# Altium schematic sheet OUT_B5.SchDoc
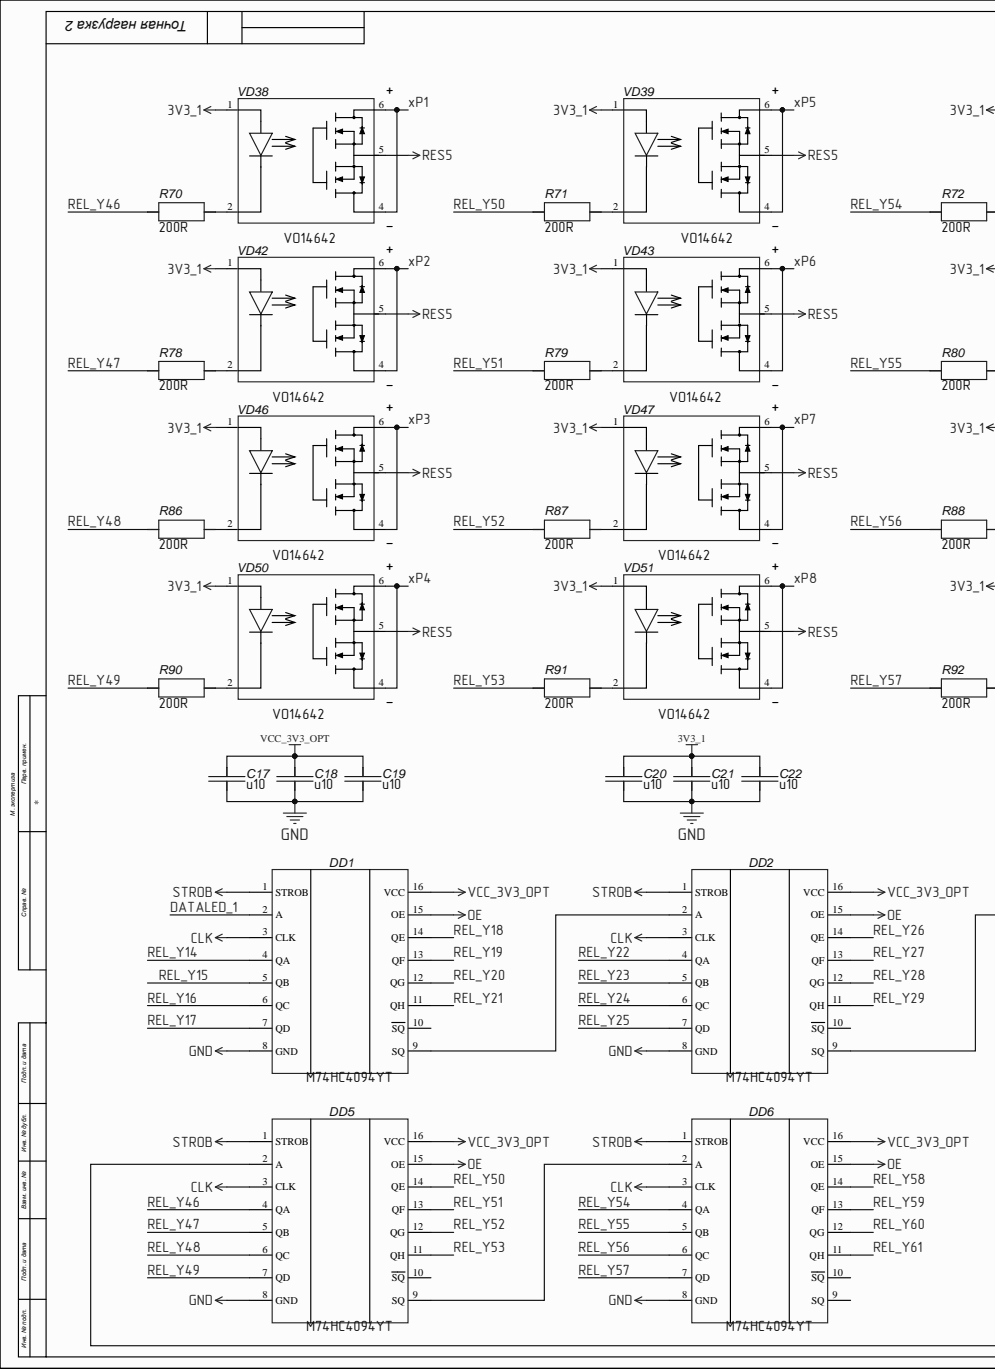
[diagram p0: OUT_B5.SchDoc, left]
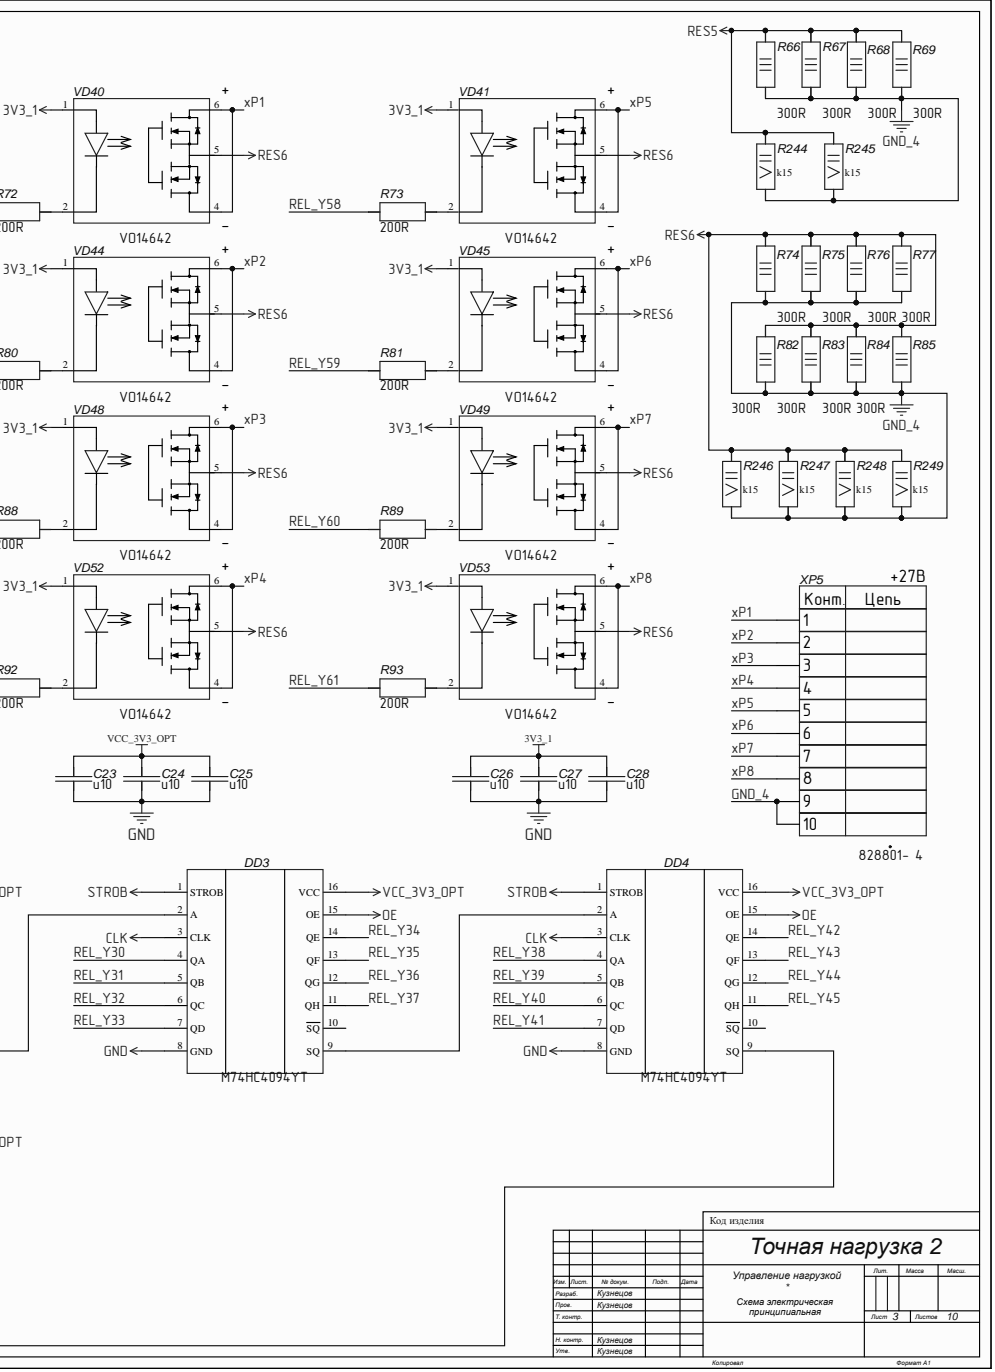
[diagram p1: OUT_B5.SchDoc, right]

## Parts
C17  u10
C18  u10
C19  u10
C20  u10
C21  u10
C22  u10
C23  u10
C24  u10
C25  u10
C26  u10
C27  u10
C28  u10
DD1  M74HC4094YT  [TSOOP-16]
DD2  M74HC4094YT  [TSOOP-16]
DD3  M74HC4094YT  [TSOOP-16]
DD4  M74HC4094YT  [TSOOP-16]
DD5  M74HC4094YT  [TSOOP-16]
DD6  M74HC4094YT  [TSOOP-16]
R66  value 300R  [R3_0]  (hidden comment: PAC03)
R67  value 300R  [R3_0]  (hidden comment: PAC03)
R68  value 300R  [R3_0]  (hidden comment: PAC03)
R69  value 300R  [R3_0]  (hidden comment: PAC03)
R70  200R  [0805]
R71  200R  [0805]
R72  200R  [0805]
R73  200R  [0805]
R74  300R  [R3_0]
R75  value 300R  [R3_0]  (hidden comment: PAC03)
R76  value 300R  [R3_0]  (hidden comment: PAC03)
R77  value 300R  [R3_0]  (hidden comment: PAC03)
R78  200R  [0805]
R79  200R  [0805]
R80  200R  [0805]
R81  200R  [0805]
R82  value 300R  [R3_0]  (hidden comment: PAC03)
R83  value 300R  [R3_0]  (hidden comment: PAC03)
R84  value 300R  [R3_0]  (hidden comment: PAC03)
R85  value 300R  [R3_0]  (hidden comment: PAC03)
R86  200R  [0805]
R87  200R  [0805]
R88  200R  [0805]
R89  200R  [0805]
R90  200R  [0805]
R91  200R  [0805]
R92  200R  [0805]
R93  200R  [0805]
R244  value k15  [RH005  7,5W]  (hidden comment: RH005)
R245  value k15  [RH005  7,5W]  (hidden comment: RH005)
R246  value k15  [RH005  7,5W]  (hidden comment: RH005)
R247  value k15  [RH005  7,5W]  (hidden comment: RH005)
R248  value k15  [RH005  7,5W]  (hidden comment: RH005)
R249  value k15  [RH005  7,5W]  (hidden comment: RH005)
VD38  VO14642  [SMD-6]
VD39  VO14642  [SMD-6]
VD40  VO14642  [SMD-6]
VD41  VO14642  [SMD-6]
VD42  VO14642  [SMD-6]
VD43  VO14642  [SMD-6]
VD44  VO14642  [SMD-6]
VD45  VO14642  [SMD-6]
VD46  VO14642  [SMD-6]
VD47  VO14642  [SMD-6]
VD48  VO14642  [SMD-6]
VD49  VO14642  [SMD-6]
VD50  VO14642  [SMD-6]
VD51  VO14642  [SMD-6]
VD52  VO14642  [SMD-6]
VD53  VO14642  [SMD-6]
XP5  828801- 4  [828801-4]

## Nets
3V3_1: C20.2 C21.2 C22.2 C26.2 C27.2 C28.2 VD38.1 VD39.1 VD40.1 VD41.1 VD42.1 VD43.1 VD44.1 VD45.1 VD46.1 VD47.1 VD48.1 VD49.1 VD50.1 VD51.1 VD52.1 VD53.1
CLK: DD1.3(CLK) DD2.3(CLK) DD3.3(CLK) DD4.3(CLK) DD5.3(CLK) DD6.3(CLK)
GND: C17.1 C18.1 C19.1 C20.1 C21.1 C22.1 C23.1 C24.1 C25.1 C26.1 C27.1 C28.1 DD1.8(GND) DD2.8(GND) DD3.8(GND) DD4.8(GND) DD5.8(GND) DD6.8(GND)
OE: DD1.15(OE) DD2.15(OE) DD3.15(OE) DD4.15(OE) DD5.15(OE) DD6.15(OE)
RES5: R66.2 R67.2 R68.2 R69.2 R244.2 R245.2 VD38.5 VD39.5 VD42.5 VD43.5 VD46.5 VD47.5 VD50.5 VD51.5
RES6: R74.2 R75.2 R76.2 R77.2 R82.2 R83.2 R84.2 R85.2 R246.2 R247.2 R248.2 R249.2 VD40.5 VD41.5 VD44.5 VD45.5 VD48.5 VD49.5 VD52.5 VD53.5
STROB: DD1.1(STROB) DD2.1(STROB) DD3.1(STROB) DD4.1(STROB) DD5.1(STROB) DD6.1(STROB)
VCC_3V3_OPT: C17.2 C18.2 C19.2 C23.2 C24.2 C25.2 DD1.16(VCC) DD2.16(VCC) DD3.16(VCC) DD4.16(VCC) DD5.16(VCC) DD6.16(VCC)
DATALED_1: DD1.2(A)
GND_4: R66.1 R67.1 R68.1 R69.1 R74.1 R75.1 R76.1 R77.1 R82.1 R83.1 R84.1 R85.1 R244.1 R245.1 R246.1 R247.1 R248.1 R249.1 XP5.9 XP5.10
REL_Y14: DD1.4(QA)
REL_Y15: DD1.5(QB)
REL_Y16: DD1.6(QC)
REL_Y17: DD1.7(QD)
REL_Y18: DD1.14(QE)
REL_Y19: DD1.13(QF)
REL_Y20: DD1.12(QG)
REL_Y21: DD1.11(QH)
REL_Y22: DD2.4(QA)
REL_Y23: DD2.5(QB)
REL_Y24: DD2.6(QC)
REL_Y25: DD2.7(QD)
REL_Y26: DD2.14(QE)
REL_Y27: DD2.13(QF)
REL_Y28: DD2.12(QG)
REL_Y29: DD2.11(QH)
REL_Y30: DD3.4(QA)
REL_Y31: DD3.5(QB)
REL_Y32: DD3.6(QC)
REL_Y33: DD3.7(QD)
REL_Y34: DD3.14(QE)
REL_Y35: DD3.13(QF)
REL_Y36: DD3.12(QG)
REL_Y37: DD3.11(QH)
REL_Y38: DD4.4(QA)
REL_Y39: DD4.5(QB)
REL_Y40: DD4.6(QC)
REL_Y41: DD4.7(QD)
REL_Y42: DD4.14(QE)
REL_Y43: DD4.13(QF)
REL_Y44: DD4.12(QG)
REL_Y45: DD4.11(QH)
REL_Y46: DD5.4(QA) R70.2
REL_Y47: DD5.5(QB) R78.2
REL_Y48: DD5.6(QC) R86.2
REL_Y49: DD5.7(QD) R90.2
REL_Y50: DD5.14(QE) R71.2
REL_Y51: DD5.13(QF) R79.2
REL_Y52: DD5.12(QG) R87.2
REL_Y53: DD5.11(QH) R91.2
REL_Y54: DD6.4(QA) R72.2
REL_Y55: DD6.5(QB) R80.2
REL_Y56: DD6.6(QC) R88.2
REL_Y57: DD6.7(QD) R92.2
REL_Y58: DD6.14(QE) R73.2
REL_Y59: DD6.13(QF) R81.2
REL_Y60: DD6.12(QG) R89.2
REL_Y61: DD6.11(QH) R93.2
xP1: VD38.4 VD38.6 VD40.4 VD40.6 XP5.1
xP2: VD42.4 VD42.6 VD44.4 VD44.6 XP5.2
xP3: VD46.4 VD46.6 VD48.4 VD48.6 XP5.3
xP4: VD50.4 VD50.6 VD52.4 VD52.6 XP5.4
xP5: VD39.4 VD39.6 VD41.4 VD41.6 XP5.5
xP6: VD43.4 VD43.6 VD45.4 VD45.6 XP5.6
xP7: VD47.4 VD47.6 VD49.4 VD49.6 XP5.7
xP8: VD51.4 VD51.6 VD53.4 VD53.6 XP5.8
NetDD1_9: DD1.9(SQ) DD2.2(A)
NetDD2_9: DD2.9(SQ) DD3.2(A)
NetDD3_9: DD3.9(SQ) DD4.2(A)
NetDD4_9: DD4.9(SQ) DD5.2(A)
NetDD5_9: DD5.9(SQ) DD6.2(A)
NetR70_1: R70.1 VD38.2
NetR71_1: R71.1 VD39.2
NetR72_1: R72.1 VD40.2
NetR73_1: R73.1 VD41.2
NetR78_1: R78.1 VD42.2
NetR79_1: R79.1 VD43.2
NetR80_1: R80.1 VD44.2
NetR81_1: R81.1 VD45.2
NetR86_1: R86.1 VD46.2
NetR87_1: R87.1 VD47.2
NetR88_1: R88.1 VD48.2
NetR89_1: R89.1 VD49.2
NetR90_1: R90.1 VD50.2
NetR91_1: R91.1 VD51.2
NetR92_1: R92.1 VD52.2
NetR93_1: R93.1 VD53.2

Not connected: DD1 10(SQ); DD2 10(SQ); DD3 10(SQ); DD4 10(SQ); DD5 10(SQ); DD6 9(SQ) 10(SQ)

## Library data of the parts
C17-C28 = (no symbol name): Capacitor; Power\Voltage: 25V; TKE: X5R; Tolerance: 10%; Корпус: 0805; Component Kind: Standard; ComponentLink1Description: Параметры; ComponentLink2Description: Сайт производителя; Value: u10; Author: Eleman; Library Name: eleman_capacitor.schlib
DD1-DD6 = M74HC4094YT: Микросхема  8-разрядный сдвиговый регистр; Корпус: 16-TSSOP; Component Kind: Standard; ComponentLink1Description: Параметры; ComponentLink2Description: Сайт производителя; ManufacturerPartNumber: M74HC4094YT; Author: Eleman; Library Name: Eleman_Logic.SchLib
R66-R69 R82-R85 = PAC03: Импортный постоянный углеродистый резистор; Manufacturer: Vishay Dale; ManufacturerPartNumber: PAC300003000FAC000; Power\Voltage: 3W; Tolerance: 1%; Component Kind: Standard; ComponentLink1Description: Параметры; ComponentLink2Description: Сайт производителя; Author: Eleman; ComponentLink1URL: d:\YandexDisk\Project_Altium\Lib_Eleman\; Library Name: Eleman_Resistor.SchLib
R70-R73 R78-R81 R86-R93 = R0805: Импортный постоянный углеродистый резистор; Manufacturer: Vishay Dale; Power\Voltage: 0.125W; Tolerance: 5%; Component Kind: Standard; ComponentLink1Description: Параметры; ComponentLink2Description: Сайт производителя; ManufacturerPartNumber: R0805; Value: 200R; Корпус: SMD 0805; Author: Eleman; Library Name: Eleman_Resistor.SchLib
R74 = PAC03: Импортный постоянный углеродистый резистор; Manufacturer: Vishay Dale; ManufacturerPartNumber: PAC300003000FAC000; Power\Voltage: 3W; Tolerance: 1%; Корпус: Axial; Component Kind: Standard; ComponentLink1Description: Параметры; ComponentLink2Description: Сайт производителя; Value: 300R; Author: Eleman; ComponentLink1URL: d:\YandexDisk\Project_Altium\Lib_Eleman\; Library Name: Eleman_Resistor.SchLib
R75-R77 = PAC03: Импортный постоянный углеродистый резистор; Manufacturer: Vishay Dale; ManufacturerPartNumber: PAC300003000FAC000; Power\Voltage: 3W; Tolerance: 1%; Value: 300R; Component Kind: Standard; ComponentLink1Description: Параметры; ComponentLink2Description: Сайт производителя; Author: Eleman; ComponentLink1URL: d:\YandexDisk\Project_Altium\Lib_Eleman\; Library Name: Eleman_Resistor.SchLib
R244-R249 = RH005_7W: Импортный постоянный углеродистый резистор; Manufacturer: Vishay Dale; ManufacturerPartNumber: RH005_; Power\Voltage: 7W; Tolerance: 1%; Component Kind: Standard; ComponentLink1Description: Параметры; ComponentLink2Description: Сайт производителя; Author: Eleman; ComponentLink1URL: d:\YandexDisk\Project_Altium\Lib_Eleman\; Library Name: Eleman_Resistor.SchLib
VD38-VD53 = VO14642: Оптотранзистор; Manufacturer: Vishay Semiconductor Opto Division; Power\Voltage: IN 1.3V  50mA   OUT 2A 250mOhm; Корпус: 6-SMD; Component Kind: Standard; ComponentLink1Description: Параметры; ComponentLink2Description: Сайт производителя; ManufacturerPartNumber: VO14642; Supplier 1: Digi-Key; Supplier Part Number 1: VO14642AABTR-ND; Author: Eleman; ComponentLink1URL: d:\YandexDisk\Project_Altium\Lib_Eleman\; Library Name: Eleman_Opto.SchLib
XP5 = 828801- 4: Разъем; Manufacturer: TE Connectivity AMP Connectors; Component Kind: Standard; ComponentLink1Description: Параметры; ComponentLink2Description: Сайт производителя; ManufacturerPartNumber: 828801- 4; Author: Eleman; ComponentLink1URL: C:\Demo\Datasheet; Library Name: Eleman_Connector.SchLib
